# Altium schematic sheet controllers.SchDoc(controllers)
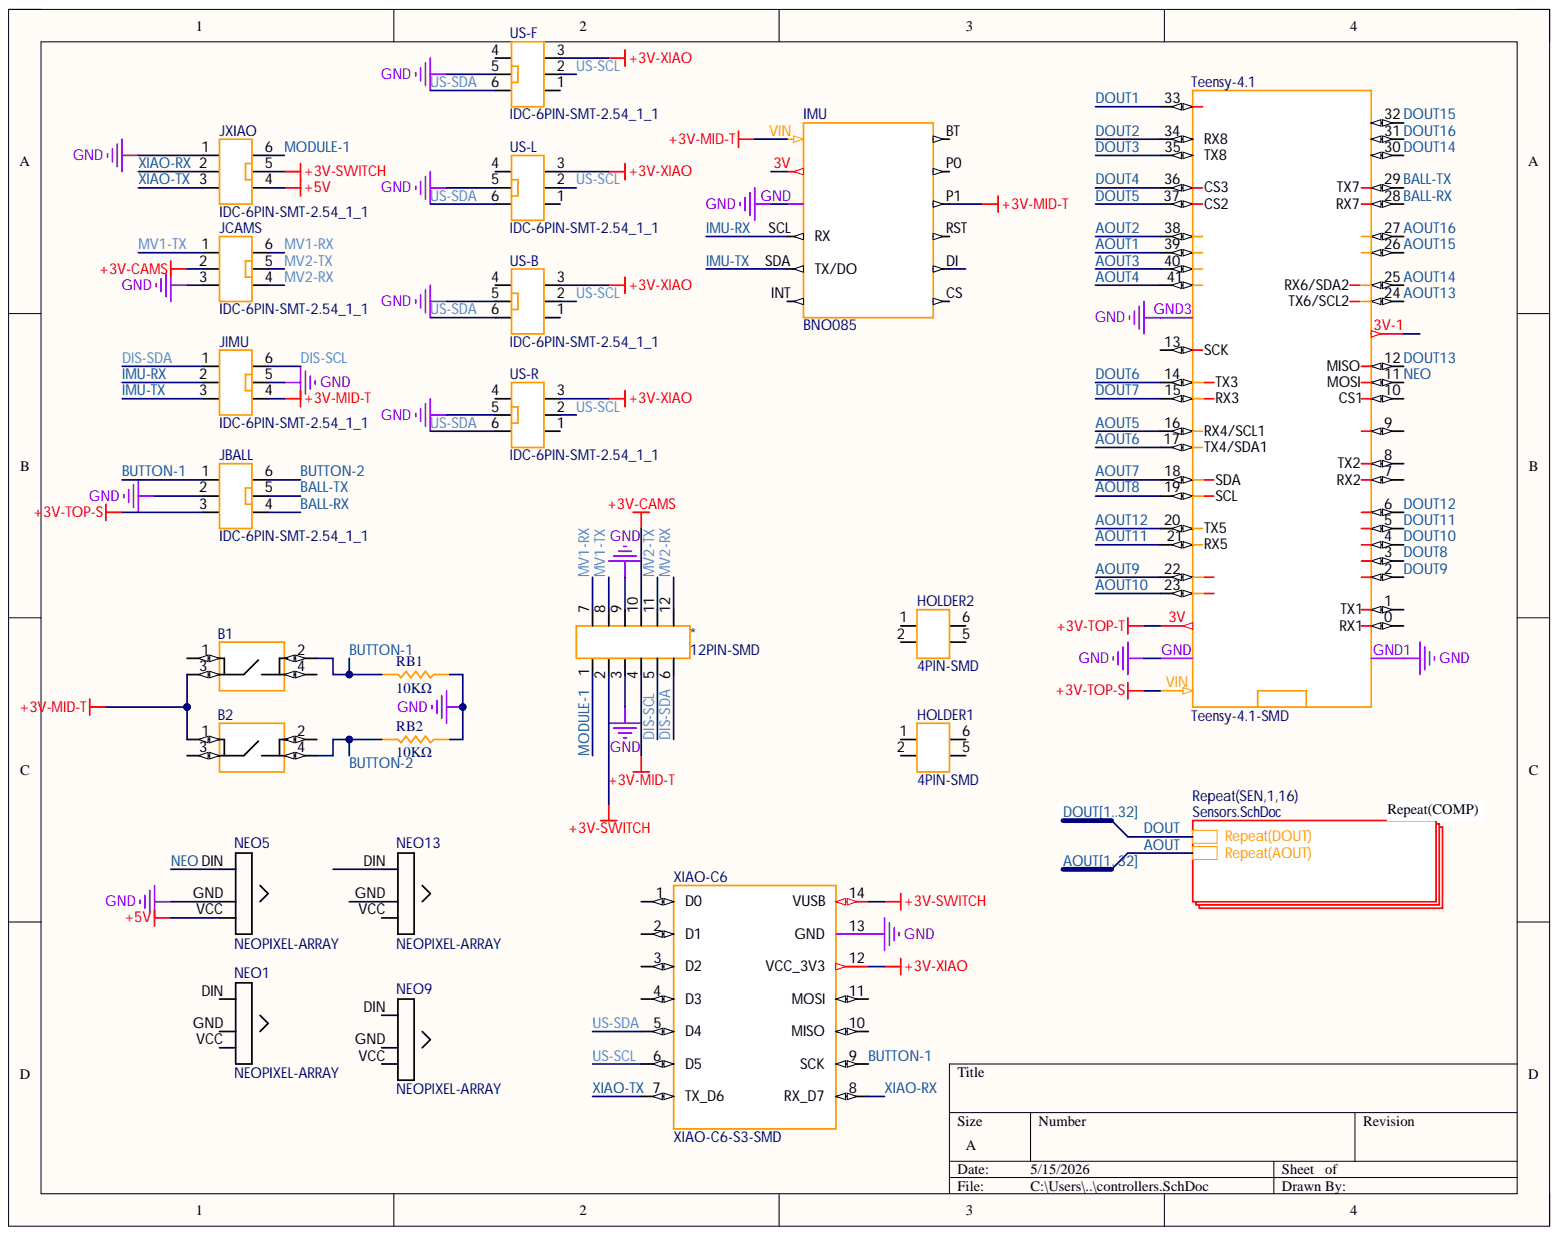
[diagram p0: controllers.SchDoc]

## Parts
*  12PIN-SMD  [12PIN-SMD]
B1  BUTTON  [BUTTON]  (value not drawn)
B2  BUTTON  [BUTTON]  (value not drawn)
HOLDER1  4PIN-SMD  [4PIN-SMD]
HOLDER2  4PIN-SMD  [4PIN-SMD]
IMU  BNO085  [BNO085]
JBALL  IDC-6PIN-SMT-2.54_1_1  [IDC-6PIN-SMT-2.54]
JCAMS  IDC-6PIN-SMT-2.54_1_1  [IDC-6PIN-SMT-2.54]
JIMU  IDC-6PIN-SMT-2.54_1_1  [IDC-6PIN-SMT-2.54]
JXIAO  IDC-6PIN-SMT-2.54_1_1  [IDC-6PIN-SMT-2.54]
NEO1  NEOPIXEL-ARRAY  [NEOPIXEL-ARRAY]
NEO5  NEOPIXEL-ARRAY  [NEOPIXEL-ARRAY]
NEO9  NEOPIXEL-ARRAY  [NEOPIXEL-ARRAY]
NEO13  NEOPIXEL-ARRAY  [NEOPIXEL-ARRAY]
RB1  10KΩ  [RES_0603]
RB2  10KΩ  [RES_0603]
Teensy-4.1  Teensy-4.1-SMD  [TEENSY-4.1-SMD]
US-B  IDC-6PIN-SMT-2.54_1_1  [IDC-6PIN-SMT-2.54]
US-F  IDC-6PIN-SMT-2.54_1_1  [IDC-6PIN-SMT-2.54]
US-L  IDC-6PIN-SMT-2.54_1_1  [IDC-6PIN-SMT-2.54]
US-R  IDC-6PIN-SMT-2.54_1_1  [IDC-6PIN-SMT-2.54]
XIAO-C6  XIAO-C6-S3-SMD  [XIAO-C6-S3-SMD]

## Nets
+3V-CAMS: *.10 JCAMS.2
+3V-MID-T: *.4 B1.3 B2.1 IMU.P1 IMU.VIN JIMU.4
+3V-SWITCH: *.2 JXIAO.5 XIAO-C6.14(VUSB)
+3V-TOP-S: JBALL.3 Teensy-4.1.VIN
+3V-TOP-T: Teensy-4.1.3V
+3V-XIAO: US-B.3 US-F.3 US-L.3 US-R.3 XIAO-C6.12(VCC_3V3)
+5V: JXIAO.4 NEO5.VCC
GND: *.3 *.9 IMU.GND JBALL.2 JCAMS.3 JIMU.5 JXIAO.1 NEO5.GND RB1.2 RB2.2 Teensy-4.1.GND Teensy-4.1.GND1 Teensy-4.1.GND3 US-B.5 US-F.5 US-L.5 US-R.5 XIAO-C6.13(GND)
AOUT1: Teensy-4.1.39  [also labelled AOUT]
AOUT2: Teensy-4.1.38  [also labelled AOUT]
AOUT3: Teensy-4.1.40  [also labelled AOUT]
AOUT4: Teensy-4.1.41  [also labelled AOUT]
AOUT5: Teensy-4.1.16(RX4/SCL1)  [also labelled AOUT]
AOUT6: Teensy-4.1.17(TX4/SDA1)  [also labelled AOUT]
AOUT7: Teensy-4.1.18(SDA)  [also labelled AOUT]
AOUT8: Teensy-4.1.19(SCL)  [also labelled AOUT]
AOUT9: Teensy-4.1.22  [also labelled AOUT]
AOUT10: Teensy-4.1.23  [also labelled AOUT]
AOUT11: Teensy-4.1.21(RX5)  [also labelled AOUT]
AOUT12: Teensy-4.1.20(TX5)  [also labelled AOUT]
AOUT13: Teensy-4.1.24(TX6/SCL2)  [also labelled AOUT]
AOUT14: Teensy-4.1.25(RX6/SDA2)  [also labelled AOUT]
AOUT15: Teensy-4.1.26  [also labelled AOUT]
AOUT16: Teensy-4.1.27  [also labelled AOUT]
BALL-RX: JBALL.4 Teensy-4.1.28(RX7)
BALL-TX: JBALL.5 Teensy-4.1.29(TX7)
BUTTON-1: B1.2 JBALL.1 RB1.1 XIAO-C6.9(SCK)
BUTTON-2: B2.4 JBALL.6 RB2.1
DIS-SCL: *.5 JIMU.6
DIS-SDA: *.6 JIMU.1
DOUT1: Teensy-4.1.33  [also labelled DOUT]
DOUT2: Teensy-4.1.34(RX8)  [also labelled DOUT]
DOUT3: Teensy-4.1.35(TX8)  [also labelled DOUT]
DOUT4: Teensy-4.1.36(CS3)  [also labelled DOUT]
DOUT5: Teensy-4.1.37(CS2)  [also labelled DOUT]
DOUT6: Teensy-4.1.14(TX3)  [also labelled DOUT]
DOUT7: Teensy-4.1.15(RX3)  [also labelled DOUT]
DOUT8: Teensy-4.1.3  [also labelled DOUT]
DOUT9: Teensy-4.1.2  [also labelled DOUT]
DOUT10: Teensy-4.1.4  [also labelled DOUT]
DOUT11: Teensy-4.1.5  [also labelled DOUT]
DOUT12: Teensy-4.1.6  [also labelled DOUT]
DOUT13: Teensy-4.1.12(MISO)  [also labelled DOUT]
DOUT14: Teensy-4.1.30  [also labelled DOUT]
DOUT15: Teensy-4.1.32  [also labelled DOUT]
DOUT16: Teensy-4.1.31  [also labelled DOUT]
IMU-RX: IMU.SCL(RX) JIMU.2
IMU-TX: IMU.SDA(TX/DO) JIMU.3
MODULE-1: *.1 JXIAO.6
MV1-RX: *.7 JCAMS.6
MV1-TX: *.8 JCAMS.1
MV2-RX: *.12 JCAMS.4
MV2-TX: *.11 JCAMS.5
NEO: NEO5.DIN Teensy-4.1.11(MOSI)
US-SCL: US-B.2 US-F.2 US-L.2 US-R.2 XIAO-C6.6(D5)
US-SDA: US-B.6 US-F.6 US-L.6 US-R.6 XIAO-C6.5(D4)
XIAO-RX: JXIAO.2 XIAO-C6.8(RX_D7)
XIAO-TX: JXIAO.3 XIAO-C6.7(TX_D6)
NetB1_1: B1.1
NetB1_4: B1.4
NetB2_2: B2.2
NetB2_3: B2.3
NetHOLDER1_1: HOLDER1.1
NetHOLDER1_2: HOLDER1.2
NetHOLDER1_5: HOLDER1.5
NetHOLDER1_6: HOLDER1.6
NetHOLDER2_1: HOLDER2.1
NetHOLDER2_2: HOLDER2.2
NetHOLDER2_5: HOLDER2.5
NetHOLDER2_6: HOLDER2.6
NetIMU_3V: IMU.3V
NetIMU_BT: IMU.BT
NetIMU_CS: IMU.CS
NetIMU_DI: IMU.DI
NetIMU_INT: IMU.INT
NetIMU_P0: IMU.P0
NetIMU_RST: IMU.RST
NetNEO1_DIN: NEO1.DIN
NetNEO1_GND: NEO1.GND
NetNEO1_VCC: NEO1.VCC
NetNEO9_DIN: NEO9.DIN
NetNEO9_GND: NEO9.GND
NetNEO9_VCC: NEO9.VCC
NetNEO13_DIN: NEO13.DIN
NetNEO13_GND: NEO13.GND
NetNEO13_VCC: NEO13.VCC
NetTeensy-4.1_0: Teensy-4.1.0(RX1)
NetTeensy-4.1_1: Teensy-4.1.1(TX1)
NetTeensy-4.1_3V-1: Teensy-4.1.3V-1
NetTeensy-4.1_7: Teensy-4.1.7(RX2)
NetTeensy-4.1_8: Teensy-4.1.8(TX2)
NetTeensy-4.1_9: Teensy-4.1.9
NetTeensy-4.1_10: Teensy-4.1.10(CS1)
NetTeensy-4.1_13: Teensy-4.1.13(SCK)
NetUS-B_1: US-B.1
NetUS-B_4: US-B.4
NetUS-F_1: US-F.1
NetUS-F_4: US-F.4
NetUS-L_1: US-L.1
NetUS-L_4: US-L.4
NetUS-R_1: US-R.1
NetUS-R_4: US-R.4
NetXIAO-C6_1: XIAO-C6.1(D0)
NetXIAO-C6_2: XIAO-C6.2(D1)
NetXIAO-C6_3: XIAO-C6.3(D2)
NetXIAO-C6_4: XIAO-C6.4(D3)
NetXIAO-C6_10: XIAO-C6.10(MISO)
NetXIAO-C6_11: XIAO-C6.11(MOSI)
AOUT17:  [also labelled AOUT]
AOUT18:  [also labelled AOUT]
AOUT19:  [also labelled AOUT]
AOUT20:  [also labelled AOUT]
AOUT21:  [also labelled AOUT]
AOUT22:  [also labelled AOUT]
AOUT23:  [also labelled AOUT]
AOUT24:  [also labelled AOUT]
AOUT25:  [also labelled AOUT]
AOUT26:  [also labelled AOUT]
AOUT27:  [also labelled AOUT]
AOUT28:  [also labelled AOUT]
AOUT29:  [also labelled AOUT]
AOUT30:  [also labelled AOUT]
AOUT31:  [also labelled AOUT]
AOUT32:  [also labelled AOUT]
DOUT17:  [also labelled DOUT]
DOUT18:  [also labelled DOUT]
DOUT19:  [also labelled DOUT]
DOUT20:  [also labelled DOUT]
DOUT21:  [also labelled DOUT]
DOUT22:  [also labelled DOUT]
DOUT23:  [also labelled DOUT]
DOUT24:  [also labelled DOUT]
DOUT25:  [also labelled DOUT]
DOUT26:  [also labelled DOUT]
DOUT27:  [also labelled DOUT]
DOUT28:  [also labelled DOUT]
DOUT29:  [also labelled DOUT]
DOUT30:  [also labelled DOUT]
DOUT31:  [also labelled DOUT]
DOUT32:  [also labelled DOUT]

## Library data of the parts
RB1 RB2 = RES_0603: Resistor SMD 0603 generic; Component Kind: Standard; Library Name: RC.IntLib
* = 12PIN-SMD: Component Kind: Standard; Library Name: RC.IntLib
B1 B2 = BUTTON: Component Kind: Standard; Library Name: RC.IntLib
HOLDER1 HOLDER2 = 4PIN-SMD: Component Kind: Standard; Library Name: RC.IntLib
IMU = BNO085: Component Kind: Standard; Library Name: RC.IntLib
JBALL JCAMS JIMU JXIAO US-B US-F US-L US-R = IDC-6PIN-SMT-2.54_1_1: Component Kind: Standard; Library Name: RC.IntLib
NEO1 NEO5 NEO9 NEO13 = NEOPIXEL-ARRAY: Component Kind: Standard; Library Name: RC.IntLib
Teensy-4.1 = Teensy-4.1-SMD: Component Kind: Standard; Library Name: RC.IntLib
XIAO-C6 = XIAO-C6-S3-SMD: Component Kind: Standard; Library Name: RC.IntLib
